# Altium schematic sheet Controller.SchDoc
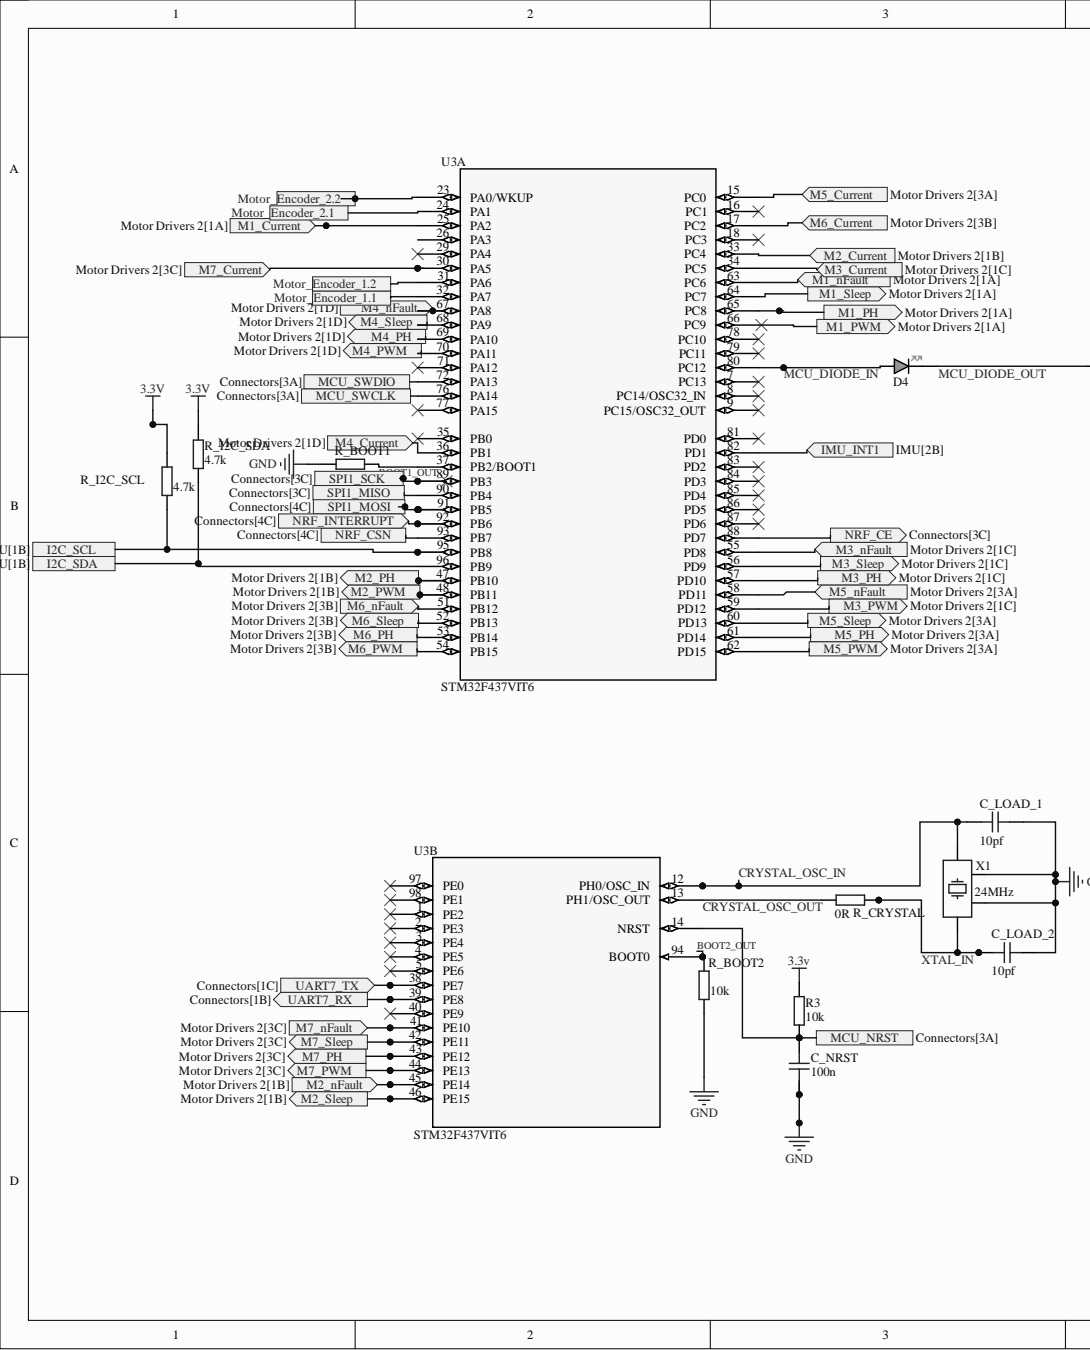
[diagram p0: Controller.SchDoc, left]
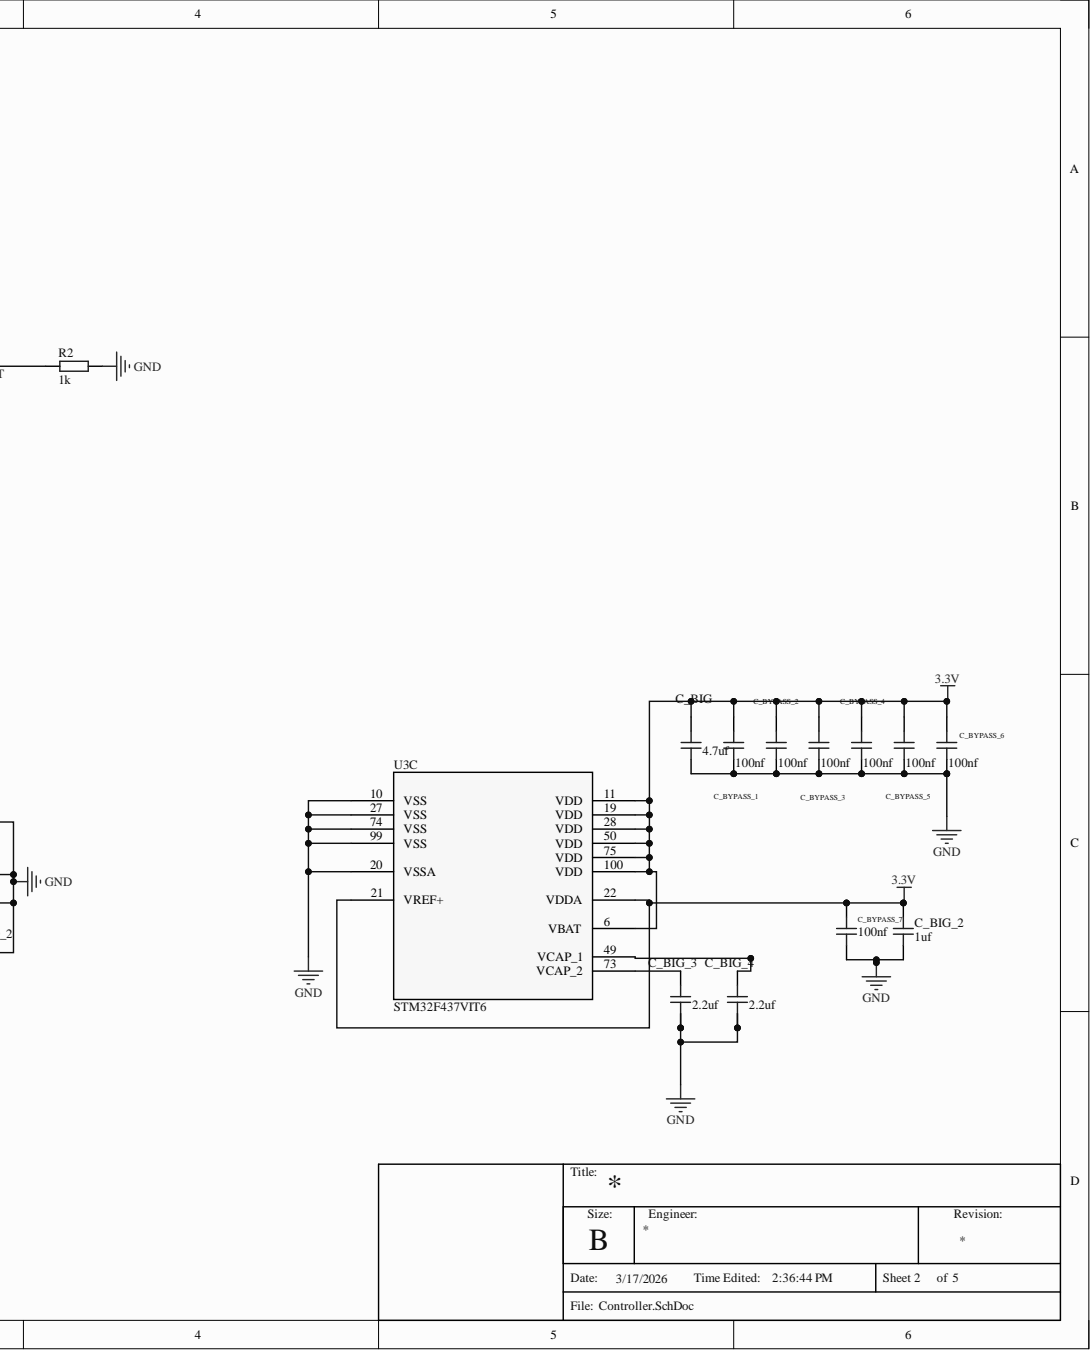
[diagram p1: Controller.SchDoc, right]

## Parts
C_BIG  4.7uf  [CAP_0603]
C_BIG_2  1uf  [CAP_0603]
C_BIG_3  2.2uf  [CAP_0603]
C_BIG_4  2.2uf  [CAP_0603]
C_BYPASS_1  100nf  [CAP_0402]
C_BYPASS_2  100nf  [CAP_0402]
C_BYPASS_3  100nf  [CAP_0402]
C_BYPASS_4  100nf  [CAP_0402]
C_BYPASS_5  100nf  [CAP_0402]
C_BYPASS_6  100nf  [CAP_0402]
C_BYPASS_7  100nf  [CAP_0402]
C_LOAD_1  10pf  [CAP_0402]
C_LOAD_2  10pf  [CAP_0402]
C_NRST  100n  [CAP_0402]
D4  GREEN  [LED_0402_GREEN]  (value not drawn)
R2  1k  [RES_0402]
R3  10k  [RES_0402]
R_BOOT1  10k  [RES_0402]
R_BOOT2  10k  [RES_0402]
R_CRYSTAL  0R  [RES_0402]
R_I2C_SCL  4.7k  [RES_0402]
R_I2C_SDA  4.7k  [RES_0402]
U3  STM32F437VIT6  [LQFP100-14x14mm]  (parts A,B,C)
X1  24MHz  [XTAL_3225]

## Ports
I2C_SCL, I2C_SDA, IMU_INT1, M1_Current, M1_nFault, M1_PH, M1_PWM, M1_Sleep, M2_Current, M2_nFault, M2_PH, M2_PWM, M2_Sleep, M3_Current, M3_nFault, M3_PH, M3_PWM, M3_Sleep, M4_Current, M4_nFault, M4_PH, M4_PWM, M4_Sleep, M5_Current, M5_nFault, M5_PH, M5_PWM, M5_Sleep, M6_Current, M6_nFault, M6_PH, M6_PWM, M6_Sleep, M7_Current, M7_nFault, M7_PH, M7_PWM, M7_Sleep, MCU_NRST, MCU_SWCLK, MCU_SWDIO, Motor_Encoder_1.1, Motor_Encoder_1.2, Motor_Encoder_2.1, Motor_Encoder_2.2, NRF_CE, NRF_CSN, NRF_INTERRUPT, SPI1_MISO, SPI1_MOSI, SPI1_SCK, UART7_RX, UART7_TX

## Nets
3.3v: C_BIG.2 C_BIG_2.1 C_BYPASS_1.2 C_BYPASS_2.2 C_BYPASS_3.2 C_BYPASS_4.2 C_BYPASS_5.2 C_BYPASS_6.2 C_BYPASS_7.1 R3.2 R_I2C_SCL.2 R_I2C_SDA.2 U3.6(VBAT) U3.11(VDD) U3.19(VDD) U3.21(VREF+) U3.22(VDDA) U3.28(VDD) U3.50(VDD) U3.75(VDD) U3.100(VDD)
GND: C_BIG.1 C_BIG_2.2 C_BIG_3.1 C_BIG_4.1 C_BYPASS_1.1 C_BYPASS_2.1 C_BYPASS_3.1 C_BYPASS_4.1 C_BYPASS_5.1 C_BYPASS_6.1 C_BYPASS_7.2 C_LOAD_1.1 C_LOAD_2.1 C_NRST.1 R2.2 R_BOOT1.2 R_BOOT2.1 U3.10(VSS) U3.20(VSSA) U3.27(VSS) U3.74(VSS) U3.99(VSS) X1.2 X1.4
BOOT1_OUT: R_BOOT1.1 U3.37(PB2/BOOT1)
BOOT2_OUT: R_BOOT2.2 U3.94(BOOT0)
CRYSTAL_OSC_IN: C_LOAD_1.2 U3.12(PH0/OSC_IN) X1.3
CRYSTAL_OSC_OUT: R_CRYSTAL.2 U3.13(PH1/OSC_OUT)
I2C_SCL: R_I2C_SCL.1 U3.95(PB8) ; port I2C_SCL
I2C_SDA: R_I2C_SDA.1 U3.96(PB9) ; port I2C_SDA
IMU_INT1: U3.82(PD1) ; port IMU_INT1
M1_Current: U3.25(PA2) ; port M1_Current
M1_nFault: U3.63(PC6) ; port M1_nFault
M1_PH: U3.65(PC8) ; port M1_PH
M1_PWM: U3.66(PC9) ; port M1_PWM
M1_Sleep: U3.64(PC7) ; port M1_Sleep
M2_Current: U3.33(PC4) ; port M2_Current
M2_nFault: U3.45(PE14) ; port M2_nFault
M2_PH: U3.47(PB10) ; port M2_PH
M2_PWM: U3.48(PB11) ; port M2_PWM
M2_Sleep: U3.46(PE15) ; port M2_Sleep
M3_Current: U3.34(PC5) ; port M3_Current
M3_nFault: U3.55(PD8) ; port M3_nFault
M3_PH: U3.57(PD10) ; port M3_PH
M3_PWM: U3.59(PD12) ; port M3_PWM
M3_Sleep: U3.56(PD9) ; port M3_Sleep
M4_Current: U3.36(PB1) ; port M4_Current
M4_nFault: U3.67(PA8) ; port M4_nFault
M4_PH: U3.69(PA10) ; port M4_PH
M4_PWM: U3.70(PA11) ; port M4_PWM
M4_Sleep: U3.68(PA9) ; port M4_Sleep
M5_Current: U3.15(PC0) ; port M5_Current
M5_nFault: U3.58(PD11) ; port M5_nFault
M5_PH: U3.61(PD14) ; port M5_PH
M5_PWM: U3.62(PD15) ; port M5_PWM
M5_Sleep: U3.60(PD13) ; port M5_Sleep
M6_Current: U3.17(PC2) ; port M6_Current
M6_nFault: U3.51(PB12) ; port M6_nFault
M6_PH: U3.53(PB14) ; port M6_PH
M6_PWM: U3.54(PB15) ; port M6_PWM
M6_Sleep: U3.52(PB13) ; port M6_Sleep
M7_Current: U3.30(PA5) ; port M7_Current
M7_nFault: U3.41(PE10) ; port M7_nFault
M7_PH: U3.43(PE12) ; port M7_PH
M7_PWM: U3.44(PE13) ; port M7_PWM
M7_Sleep: U3.42(PE11) ; port M7_Sleep
MCU_DIODE_IN: D4.A U3.80(PC12)
MCU_DIODE_OUT: D4.K R2.1
MCU_NRST: C_NRST.2 R3.1 U3.14(NRST) ; port MCU_NRST
MCU_SWCLK: U3.76(PA14) ; port MCU_SWCLK
MCU_SWDIO: U3.72(PA13) ; port MCU_SWDIO
Motor_Encoder_1.1: U3.32(PA7) ; port Motor_Encoder_1.1
Motor_Encoder_1.2: U3.31(PA6) ; port Motor_Encoder_1.2
Motor_Encoder_2.1: U3.24(PA1) ; port Motor_Encoder_2.1
Motor_Encoder_2.2: U3.23(PA0/WKUP) ; port Motor_Encoder_2.2
NRF_CE: U3.88(PD7) ; port NRF_CE
NRF_CSN: U3.93(PB7) ; port NRF_CSN
NRF_INTERRUPT: U3.92(PB6) ; port NRF_INTERRUPT
SPI1_MISO: U3.90(PB4) ; port SPI1_MISO
SPI1_MOSI: U3.91(PB5) ; port SPI1_MOSI
SPI1_SCK: U3.89(PB3) ; port SPI1_SCK
UART7_RX: U3.39(PE8) ; port UART7_RX
UART7_TX: U3.38(PE7) ; port UART7_TX
XTAL_IN: C_LOAD_2.2 R_CRYSTAL.1 X1.1
NetC_BIG_3_2: C_BIG_3.2 U3.73(VCAP_2)
NetC_BIG_4_2: C_BIG_4.2 U3.49(VCAP_1)

Not connected: U3 1(PE2) 2(PE3) 3(PE4) 4(PE5) 5(PE6) 7(PC13) 8(PC14/OSC32_IN) 9(PC15/OSC32_OUT) 16(PC1) 18(PC3) 26(PA3) 29(PA4) 35(PB0) 40(PE9) 71(PA12) 77(PA15) 78(PC10) 79(PC11) 81(PD0) 83(PD2) 84(PD3) 85(PD4) 86(PD5) 87(PD6) 97(PE0) 98(PE1)

## Library data of the parts
C_BIG C_BIG_2-C_BIG_4 = CAP_0603: Capacitor SMD 0603; Component Kind: Standard; Library Name: Schematic-Lib-PhilsLab.SchLib
C_BYPASS_1-C_BYPASS_7 C_LOAD_1 C_LOAD_2 C_NRST = CAP_0402: Capacitor SMD 0402; Component Kind: Standard; Library Name: Schematic-Lib-PhilsLab.SchLib
D4 = LED_0402_GREEN: LED 0402 GREEN SMD; Component Kind: Standard; Library Name: Schematic-Lib-PhilsLab.SchLib
R2 R3 R_BOOT1 R_BOOT2 R_CRYSTAL R_I2C_SCL R_I2C_SDA = RES_0402: Resistor SMD 0402; Component Kind: Standard; Library Name: Schematic-Lib-PhilsLab.SchLib
U3 = STM32F437VIT6: High-performance advanced line, Arm Cortex-M4 core with DSP and FPU, 2 Mbytes of Flash memory, 180 MHz CPU, ART Accelerator, Chrom-ART Accelerator, FSMC, HW crypto; Manufacturer_Name: STMicroelectronics; Component Kind: Standard; Datasheet: https://www.st.com/resource/en/datasheet/stm32f437vg.pdf; Library Name: STM32F437VIT6.IntLib; Manufacturer_Part_Number: STM32F437VIT6; RefDes: RefDes; Type: Type; Copyright: Copyright (C) 2025 Ultra Librarian. All rights reserved.
X1 = TSX-3225_24.0000MF15X-AC: Crystal SMD 24MHz 10PPM 7pF 3225; Manufacturer: Epson; Manufacturer Part Number: TSX-3225 24.0000MF15X-AC; Component Kind: Standard; Library Name: Schematic-Lib-PhilsLab.SchLib
